# Altium schematic sheet ADC_JIG.SchDoc(ADC_JIG)
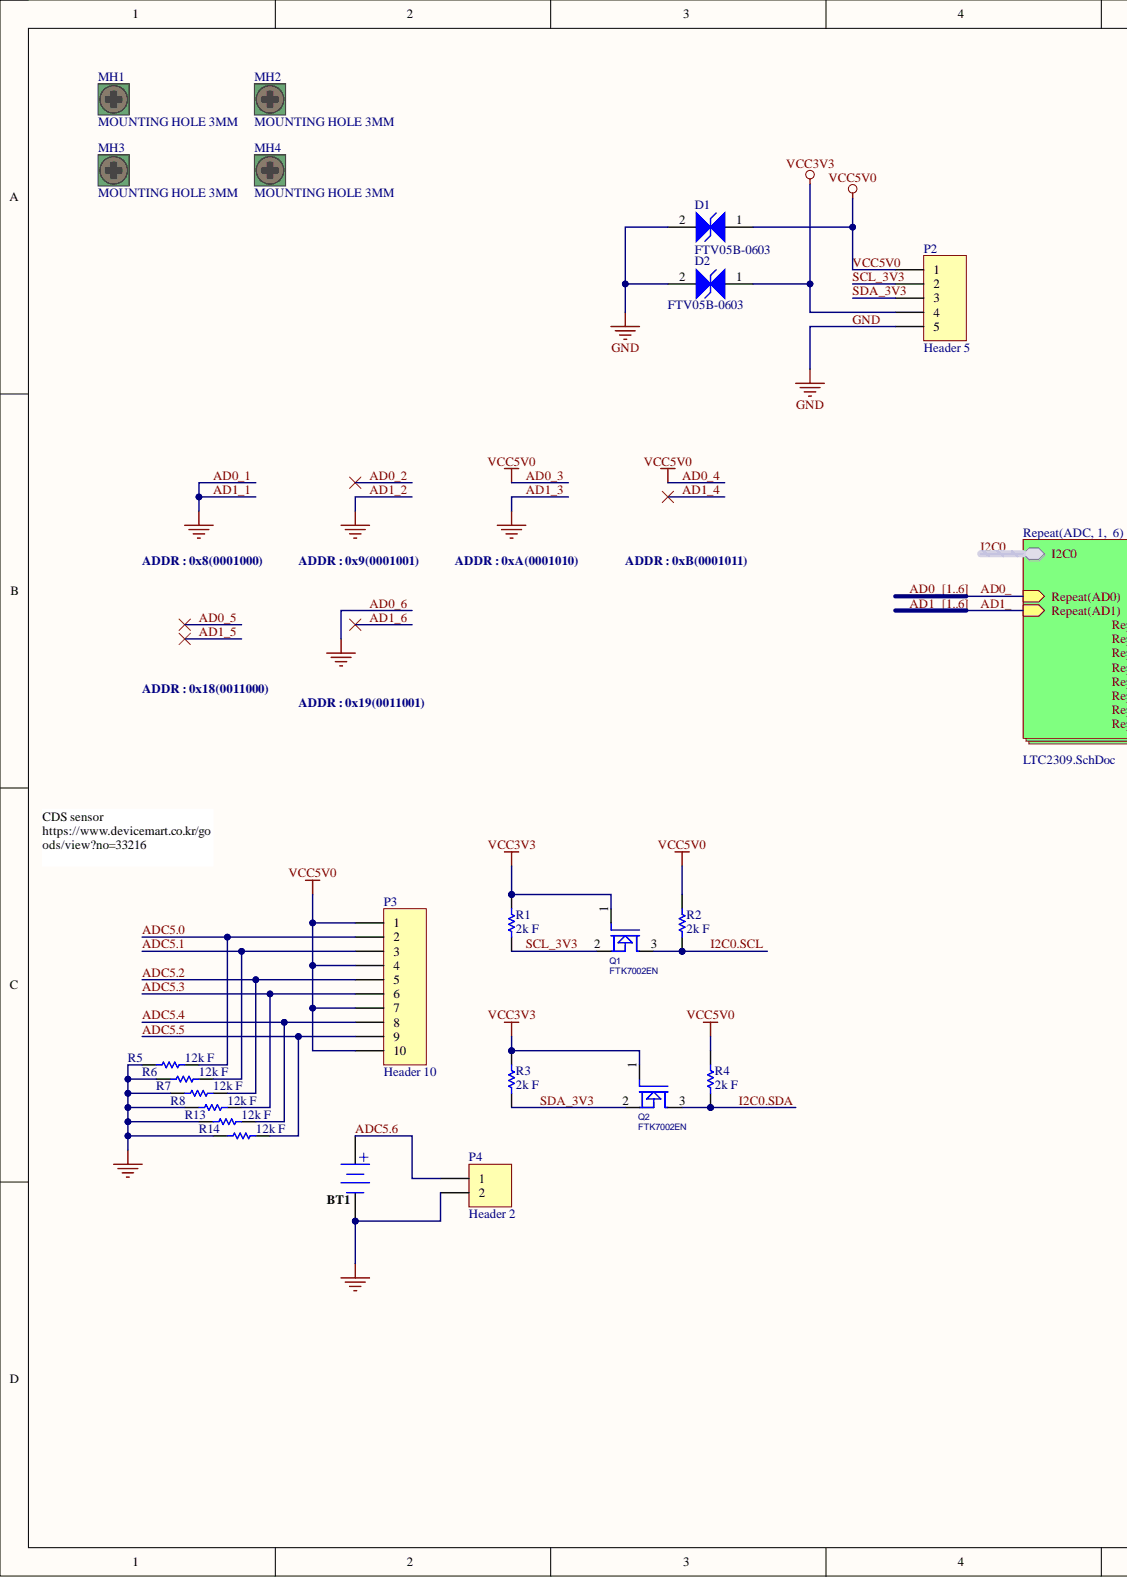
[diagram p0: ADC_JIG.SchDoc, left]
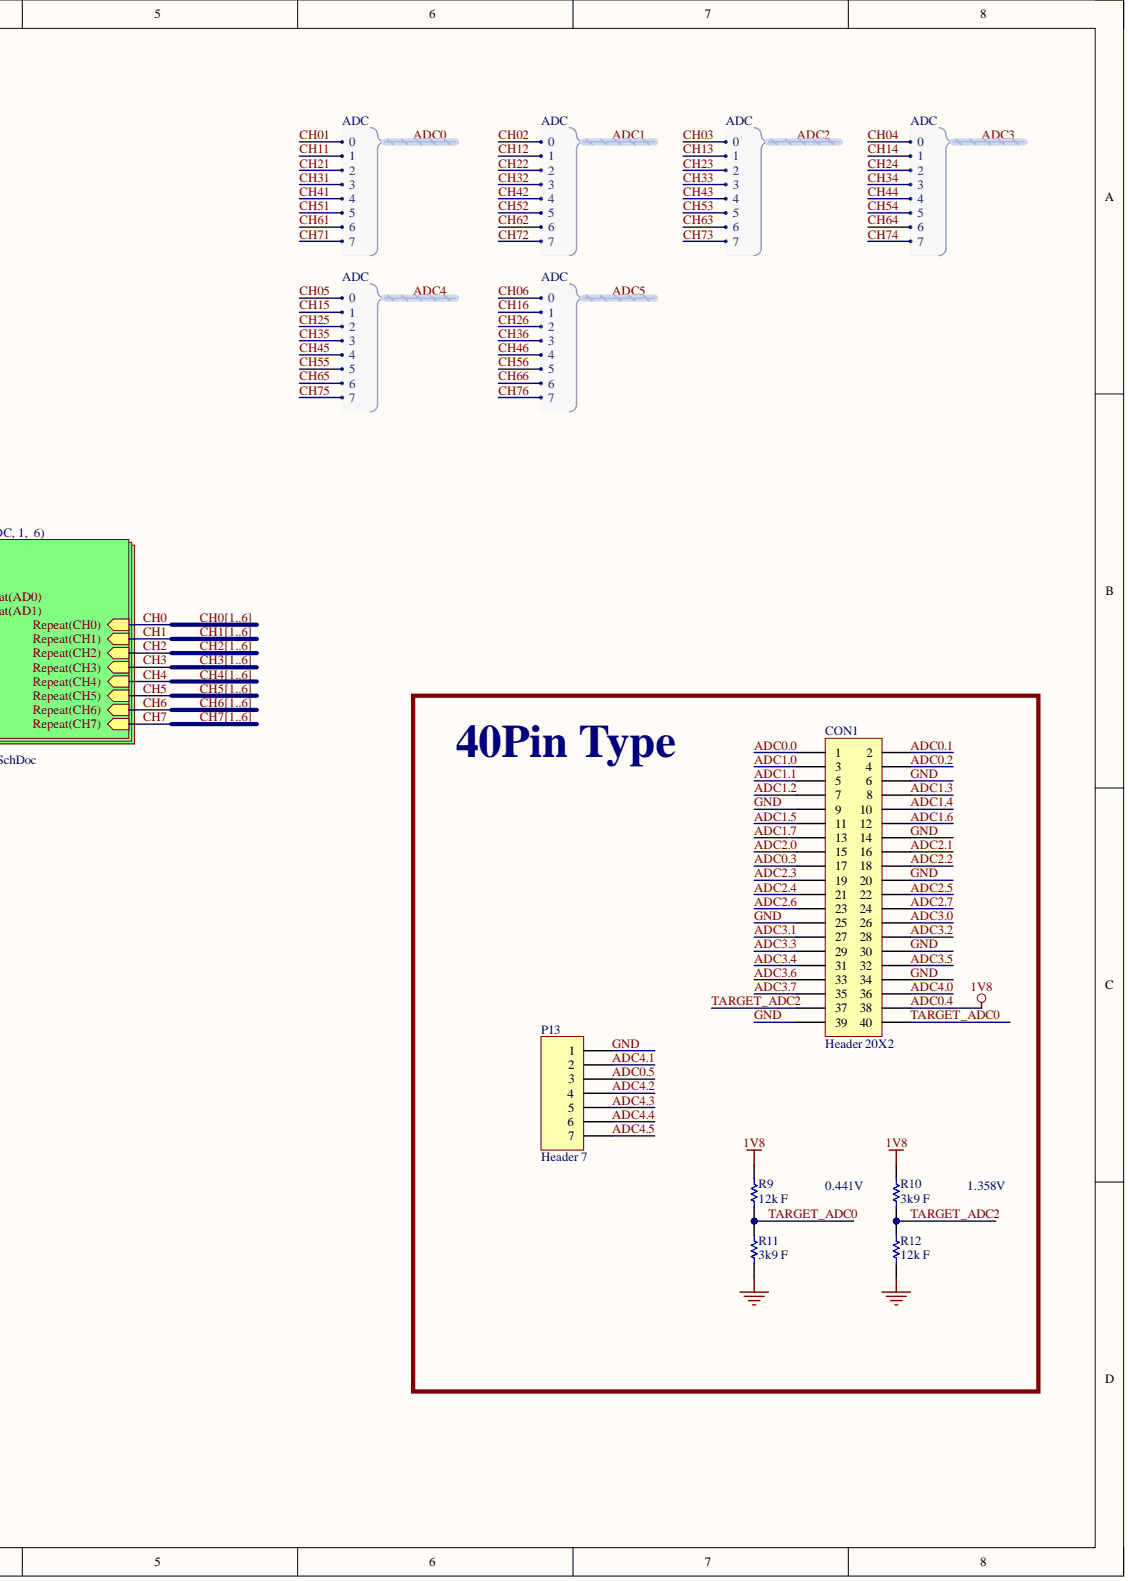
[diagram p1: ADC_JIG.SchDoc, right]

## Parts
BT1  (no value)
CON1  Header 20X2
D1  FTV05B-0603
D2  FTV05B-0603
MH1  MOUNTING HOLE 3MM  (no BOM)
MH2  MOUNTING HOLE 3MM  (no BOM)
MH3  MOUNTING HOLE 3MM  (no BOM)
MH4  MOUNTING HOLE 3MM  (no BOM)
P2  Header 5
P3  Header 10
P4  Header 2
P13  Header 7
Q1  FTK7002EN
Q2  FTK7002EN
R1  2k F
R2  2k F
R3  2k F
R4  2k F
R5  12k F
R6  12k F
R7  12k F
R8  12k F
R9  12k F
R10  3k9 F
R11  3k9 F
R12  12k F
R13  12k F
R14  12k F

## Nets
VCC3V3: D2.1 P2.4 Q1.1 Q2.1 R1.1 R3.1
AD0_1: BT1.2 BT1.3 BT1.4 CON1.6 CON1.9 CON1.14 CON1.20 CON1.25 CON1.30 CON1.34 CON1.39 D1.2 D2.2 P2.5 P4.2 P13.1 R5.1 R6.1 R7.1 R8.1 R11.2 R12.2 R13.1 R14.1  [also labelled AD0_]
AD0_1: BT1.2 BT1.3 BT1.4 CON1.6 CON1.9 CON1.14 CON1.20 CON1.25 CON1.30 CON1.34 CON1.39 D1.2 D2.2 P2.5 P4.2 P13.1 R5.1 R6.1 R7.1 R8.1 R11.2 R12.2 R13.1 R14.1  [also labelled AD0_]
AD0_3: D1.1 P2.1 P3.1 P3.4 P3.7 P3.10 R2.1 R4.1  [also labelled AD0_]
CH01: CON1.1  [also labelled CH]
CH02: CON1.3  [also labelled CH]
CH03: CON1.15  [also labelled CH]
CH04: CON1.26  [also labelled CH]
CH05: CON1.36  [also labelled CH]
CH06: P3.2 R5.2  [also labelled CH]
CH11: CON1.2  [also labelled CH]
CH12: CON1.5  [also labelled CH]
CH13: CON1.16  [also labelled CH]
CH14: CON1.27  [also labelled CH]
CH15: P13.2  [also labelled CH]
CH16: P3.3 R6.2  [also labelled CH]
CH21: CON1.4  [also labelled CH]
CH22: CON1.7  [also labelled CH]
CH23: CON1.18  [also labelled CH]
CH24: CON1.28  [also labelled CH]
CH25: P13.4  [also labelled CH]
CH26: P3.5 R7.2  [also labelled CH]
CH31: CON1.17  [also labelled CH]
CH32: CON1.8  [also labelled CH]
CH33: CON1.19  [also labelled CH]
CH34: CON1.29  [also labelled CH]
CH35: P13.5  [also labelled CH]
CH36: P3.6 R8.2  [also labelled CH]
CH41: CON1.38 R9.1 R10.1  [also labelled CH]
CH42: CON1.10  [also labelled CH]
CH43: CON1.21  [also labelled CH]
CH44: CON1.31  [also labelled CH]
CH45: P13.6  [also labelled CH]
CH46: P3.8 R13.2  [also labelled CH]
CH51: P13.3  [also labelled CH]
CH52: CON1.11  [also labelled CH]
CH53: CON1.22  [also labelled CH]
CH54: CON1.32  [also labelled CH]
CH55: P13.7  [also labelled CH]
CH56: P3.9 R14.2  [also labelled CH]
CH62: CON1.12  [also labelled CH]
CH63: CON1.23  [also labelled CH]
CH64: CON1.33  [also labelled CH]
CH66: BT1.1 P4.1  [also labelled CH]
CH72: CON1.13  [also labelled CH]
CH73: CON1.24  [also labelled CH]
CH74: CON1.35  [also labelled CH]
I2C0.SCL: Q1.3 R2.2
I2C0.SDA: Q2.3 R4.2
SCL_3V3: P2.2 Q1.2 R1.2
SDA_3V3: P2.3 Q2.2 R3.2
TARGET_ADC0: CON1.40 R9.2 R11.1
TARGET_ADC2: CON1.37 R10.2 R12.1
AD0_2:  [also labelled AD0_]
AD0_5:  [also labelled AD0_]
AD1_4:  [also labelled AD1_]
AD1_5:  [also labelled AD1_]
AD1_6:  [also labelled AD1_]
CH61:  [also labelled CH]
CH65:  [also labelled CH]
CH71:  [also labelled CH]
CH75:  [also labelled CH]
CH76:  [also labelled CH]

## Library data of the parts
BT1 = D-302-0000: 1.25 W/B CONN.SMT; Manufacturer P/N: 0532610271; Database Table Name: Connectors; Nominal output Voltage: 3 V; Category: Battery; Component Kind: Standard; Mounting Technology: Through-Hole; Status: Production; Library Name: Hardkernel.SVNDbLib
CON1 = Header 20X2: Header, 20-Pin, Dual row; Component Kind: Standard; LatestRevisionDate: 17-Jul-2002; LatestRevisionNote: Re-released for DXP Platform.; Library Name: Miscellaneous Connectors.IntLib; Publisher: Altium Limited
D1 D2 = DIO-FTV05B-0603: Bi-direction ESD Protection Diode; Manufacturer: First Silicon; Part Number: 2302; Currency: KRW; Database Table Name: Discrete; Component Kind: Standard; Component Type: Standard; Design Item Id: DIO-FTV05B-0603; Manufacturer P/N: FTV05B-0603; Package: DFNWB06030603; Library Name: Hardkernel.SVNDbLib
MH1-MH4 = MOUNTING_HOLE_3MM: Mounting Hole, M3 Screw, Plated, Square Pad; Part Number: D0010056; Database Table Name: Discrete; Component Type: Standard; Design Item Id: MOUNTING_HOLE_3MM; Library Name: Hardkernel.SVNDbLib
P2 = Header 5: Header, 5-Pin; Component Kind: Standard; LatestRevisionDate: 17-Jul-2002; LatestRevisionNote: Re-released for DXP Platform.; Library Name: Miscellaneous Connectors.IntLib; Publisher: Altium Limited
P3 = Header 10: Header, 10-Pin; Component Kind: Standard; LatestRevisionDate: 17-Jul-2002; LatestRevisionNote: Re-released for DXP Platform.; Library Name: Miscellaneous Connectors.IntLib; Publisher: Altium Limited
P4 = Header 2: Header, 2-Pin; Component Kind: Standard; LatestRevisionDate: 17-Jul-2002; LatestRevisionNote: Re-released for DXP Platform.; Library Name: Miscellaneous Connectors.IntLib; Publisher: Altium Limited
P13 = Header 7: Header, 7-Pin; Component Kind: Standard; LatestRevisionDate: 17-Jul-2002; LatestRevisionNote: Re-released for DXP Platform.; Library Name: Miscellaneous Connectors.IntLib; Publisher: Altium Limited
Q1 Q2 = FET-NCH-FTK7002EN: MOSFET N-CH 30-V (D-S) 154mA; Manufacturer: FirstSilicon; Part Number: Q001001; Currency: KRW; Database Table Name: Transistors; Component Kind: Standard; Component Type: Standard; Design Item Id: FET-NCH-FTK7002EN; Manufacturer P/N: FTK7002EN; MOQ: 3000; Package: SC-89; Quantity Available: 100; Rated Current: 154mA; Library Name: Hardkernel.SVNDbLib
R1-R4 = RES-2K-F-1005: RES 2kΩ 1% 1005; Manufacturer: 삼성전기; Manufacturer P/N: RC1005F202CS; Part Number: 99; Currency: KRW; Database Table Name: Resisters; Mfg2 PN: TSR16GF202V; Minimum Quantity: 10000; Rated Power: 0.06W; Resistance: 2kOhm; Tolerance: 0.01; Units: EA; Component Kind: Standard; Component Type: Standard; Design Item Id: RES-2K-F-1005; Mfg2: TATEYMA; Unit Price: 0.8; Library Name: Hardkernel.SVNDbLib
R5-R9 R12-R14 = RES-12K-F-1005: RES 12kΩ 1% 1005; Manufacturer: 삼성전기; Manufacturer P/N: RC1005F123CS; Part Number: 714; Currency: KRW; Database Table Name: Resisters; Mfg2 PN: CR-02FL6-12K; Minimum Quantity: 10000; Rated Power: 0.06W; Resistance: 12KOhm; Tolerance: 0.01; Units: EA; Component Kind: Standard; Component Type: Standard; Design Item Id: RES-12K-F-1005; Mfg2: DAHZAN; Unit Price: 0.8; Library Name: Hardkernel.SVNDbLib
R10 R11 = RES-3.9K-F-1005: RES 3.9kΩ 1% 1005; Manufacturer: 삼성전기; Manufacturer P/N: RC1005F392CS; Part Number: 1233; Currency: KRW; Database Table Name: Resisters; Minimum Quantity: 10000; Rated Power: 0.06W; Resistance: 100kOhm; Tolerance: 0.01; Units: EA; Component Kind: Standard; Component Type: Standard; Design Item Id: RES-3.9K-F-1005; Unit Price: 0.8; Library Name: Hardkernel.SVNDbLib
